# Altium schematic sheet Sheet1.SchDoc(Sheet1)
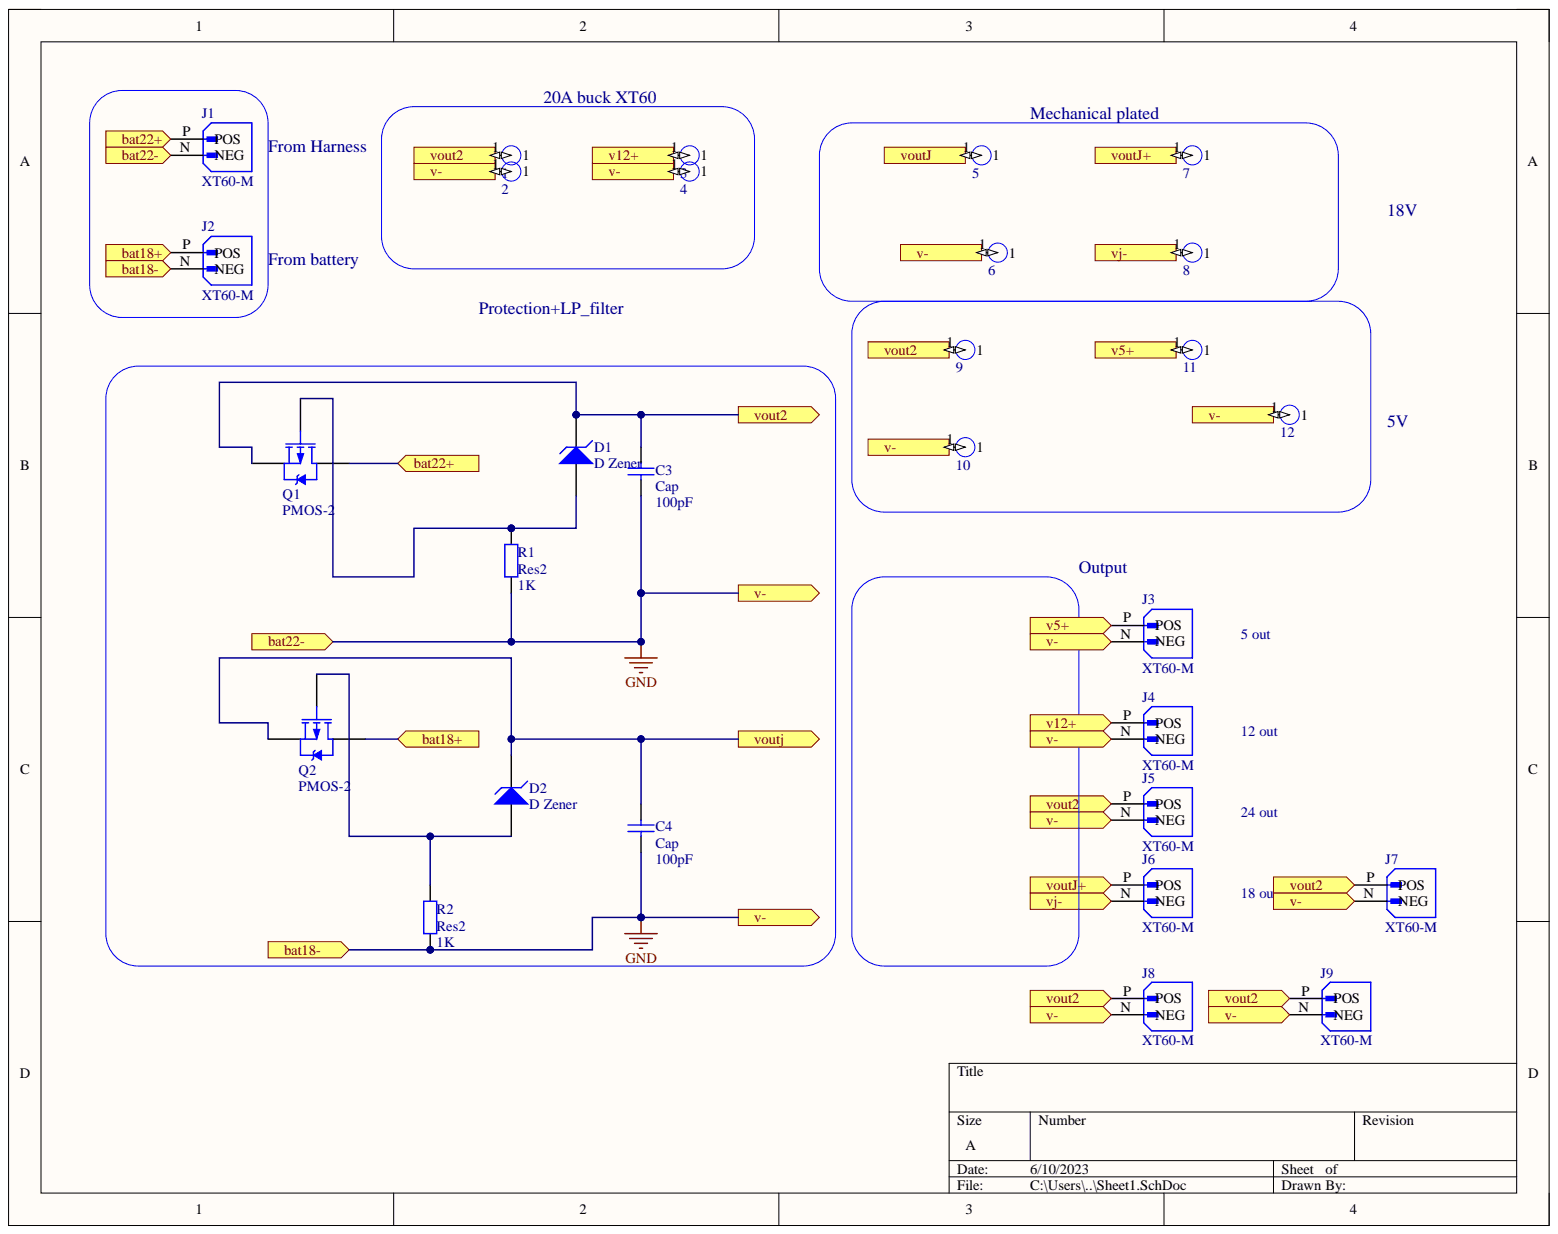
[diagram p0: Sheet1.SchDoc]

## Parts
1  mounting_h_p_10A buck  [mounting_h_p 10A buck]  (value not drawn)
2  mounting_h_p_10A buck  [mounting_h_p 10A buck]  (value not drawn)
3  mounting_h_p_10A buck  [mounting_h_p 10A buck]  (value not drawn)
4  mounting_h_p_10A buck  [mounting_h_p 10A buck]  (value not drawn)
5  mounting_h_p_10A buck  [mounting_h_p 10A buck]  (value not drawn)
6  mounting_h_p_10A buck  [mounting_h_p 10A buck]  (value not drawn)
7  mounting_h_p_10A buck  [mounting_h_p 10A buck]  (value not drawn)
8  mounting_h_p_10A buck  [mounting_h_p 10A buck]  (value not drawn)
9  mounting_h_p_10A buck  [mounting_h_p 10A buck]  (value not drawn)
10  mounting_h_p_10A buck  [mounting_h_p 10A buck]  (value not drawn)
11  mounting_h_p_10A buck  [mounting_h_p 10A buck]  (value not drawn)
12  mounting_h_p_10A buck  [mounting_h_p 10A buck]  (value not drawn)
C3  Cap  value 100pF  [RAD-0.3]
C4  Cap  value 100pF  [RAD-0.3]
D1  D Zener  [DIODE-0.7]
D2  D Zener  [DIODE-0.7]
J1  XT60-M  [AMASS_XT60-M]
J2  XT60-M  [AMASS_XT60-M]
J3  XT60-M  [AMASS_XT60-M]
J4  XT60-M  [AMASS_XT60-M]
J5  XT60-M  [AMASS_XT60-M]
J6  XT60-M  [AMASS_XT60-M]
J7  XT60-M  [AMASS_XT60-M]
J8  XT60-M  [AMASS_XT60-M]
J9  XT60-M  [AMASS_XT60-M]
Q1  PMOS-2  [TO-220AB]
Q2  PMOS-2  [TO-220AB]
R1  Res2  value 1K  [AXIAL-0.4]
R2  Res2  value 1K  [AXIAL-0.4]

## Ports
bat18+, bat18-, bat22+, bat22-, v5+, v12+, v-, vj-, vout2, voutj, voutJ, voutJ+

## Nets
GND: 2.1 4.1 6.1 10.1 12.1 C3.1 C4.1 J1.N J2.N J3.N J4.N J5.N J7.N J8.N J9.N R1.1 R2.1 ; port v-
v5+: 11.1 J3.P ; port v5+
v12+: 3.1 J4.P ; port v12+
vj-: 8.1 J6.N ; port vj-
vout2: 1.1 9.1 C3.2 D1.2 J5.P J7.P J8.P J9.P Q1.3 ; port vout2
voutJ: 5.1 C4.2 D2.2 Q2.3 ; port voutJ, port voutj
voutJ+: 7.1 J6.P ; port voutJ+
NetD1_1: D1.1 Q1.1 R1.2
NetD2_1: D2.1 Q2.1 R2.2
NetJ1_P: J1.P Q1.2
NetJ2_P: J2.P Q2.2

## Library data of the parts
C3 C4 = Cap: Capacitor; Component Kind: Standard; LatestRevisionDate: 17-Jul-2002; LatestRevisionNote: Re-released for DXP Platform.; Library Name: Miscellaneous Devices.IntLib; PackageDescription: Radial Cap, Thru-Hole; 2 Leads; 0.3 in Pin Spacing; PackageReference: RAD-0.3; Published: 8-Jun-2000; Signal Integrity: Cap; Simulation: CAP; Publisher: Altium Limited
D1 D2 = D Zener: Zener Diode; Component Kind: Standard; LatestRevisionDate: 17-Jul-2002; LatestRevisionNote: Re-released for DXP Platform.; Library Name: Miscellaneous Devices.IntLib; PackageDescription: Diode, Thru-Hole; 2 Leads; 0.7 in Pin Spacing; PackageReference: DIODE-0.7; Published: 8-Jun-2000; Signal Integrity: D Zener; Simulation: ZENER; Publisher: Altium Limited
J1-J9 = XT60-M: Plug; DC supply; XT60; male; PIN: 2; for cable; soldered; 30A; 500V; MF: AMASS; Availability: Not in stock; Check_prices: https://www.snapeda.com/parts/XT60-M/AMASS/view-part/?ref=eda; Component Kind: Standard; Library Name: XT60-M.IntLib; MP: XT60-M; Package: Package; Price: None; SnapEDA_Link: https://www.snapeda.com/parts/XT60-M/AMASS/view-part/?ref=snap
Q1 Q2 = PMOS-2: P-Channel Power MOSFET; Note: Pin 4 not drawn and electrically connected to Pin 2.; Component Kind: Standard; ComponentLink1Description: Manufacturer Link; ComponentLink1URL: http://www.irf.com/; DatasheetVersion: PD-9.390D; LatestRevisionDate: 17-Jul-2002; LatestRevisionNote: Re-released for DXP Platform.; Library Name: Miscellaneous Devices.IntLib; PackageDescription: TO, Thru-Hole, Vertical, Heatsink Mounted; 3 In-Line Leads; Pitch 2.54 mm; PackageReference: TO-220AB; PackageVersion: Feb-1997; Published: 8-Jun-2000; Simulation: IRF9510; Publisher: Altium Limited
R1 R2 = Res2: Resistor; Component Kind: Standard; LatestRevisionDate: 17-Jul-2002; LatestRevisionNote: Re-released for DXP Platform.; Library Name: Miscellaneous Devices.IntLib; PackageDescription: Axial Device, Thru-Hole; 2 Leads; 0.4 in Pin Spacing; PackageReference: AXIAL-0.4; Published: 8-Jun-2000; Signal Integrity: Res; Simulation: RESISTOR; Publisher: Altium Limited
1-12 = mounting_h_p_10A buck: Component Kind: Standard; Library Name: Schlib1.SchLib
